# Altium schematic sheet TruckCape J1708 and more CAN.SchDoc
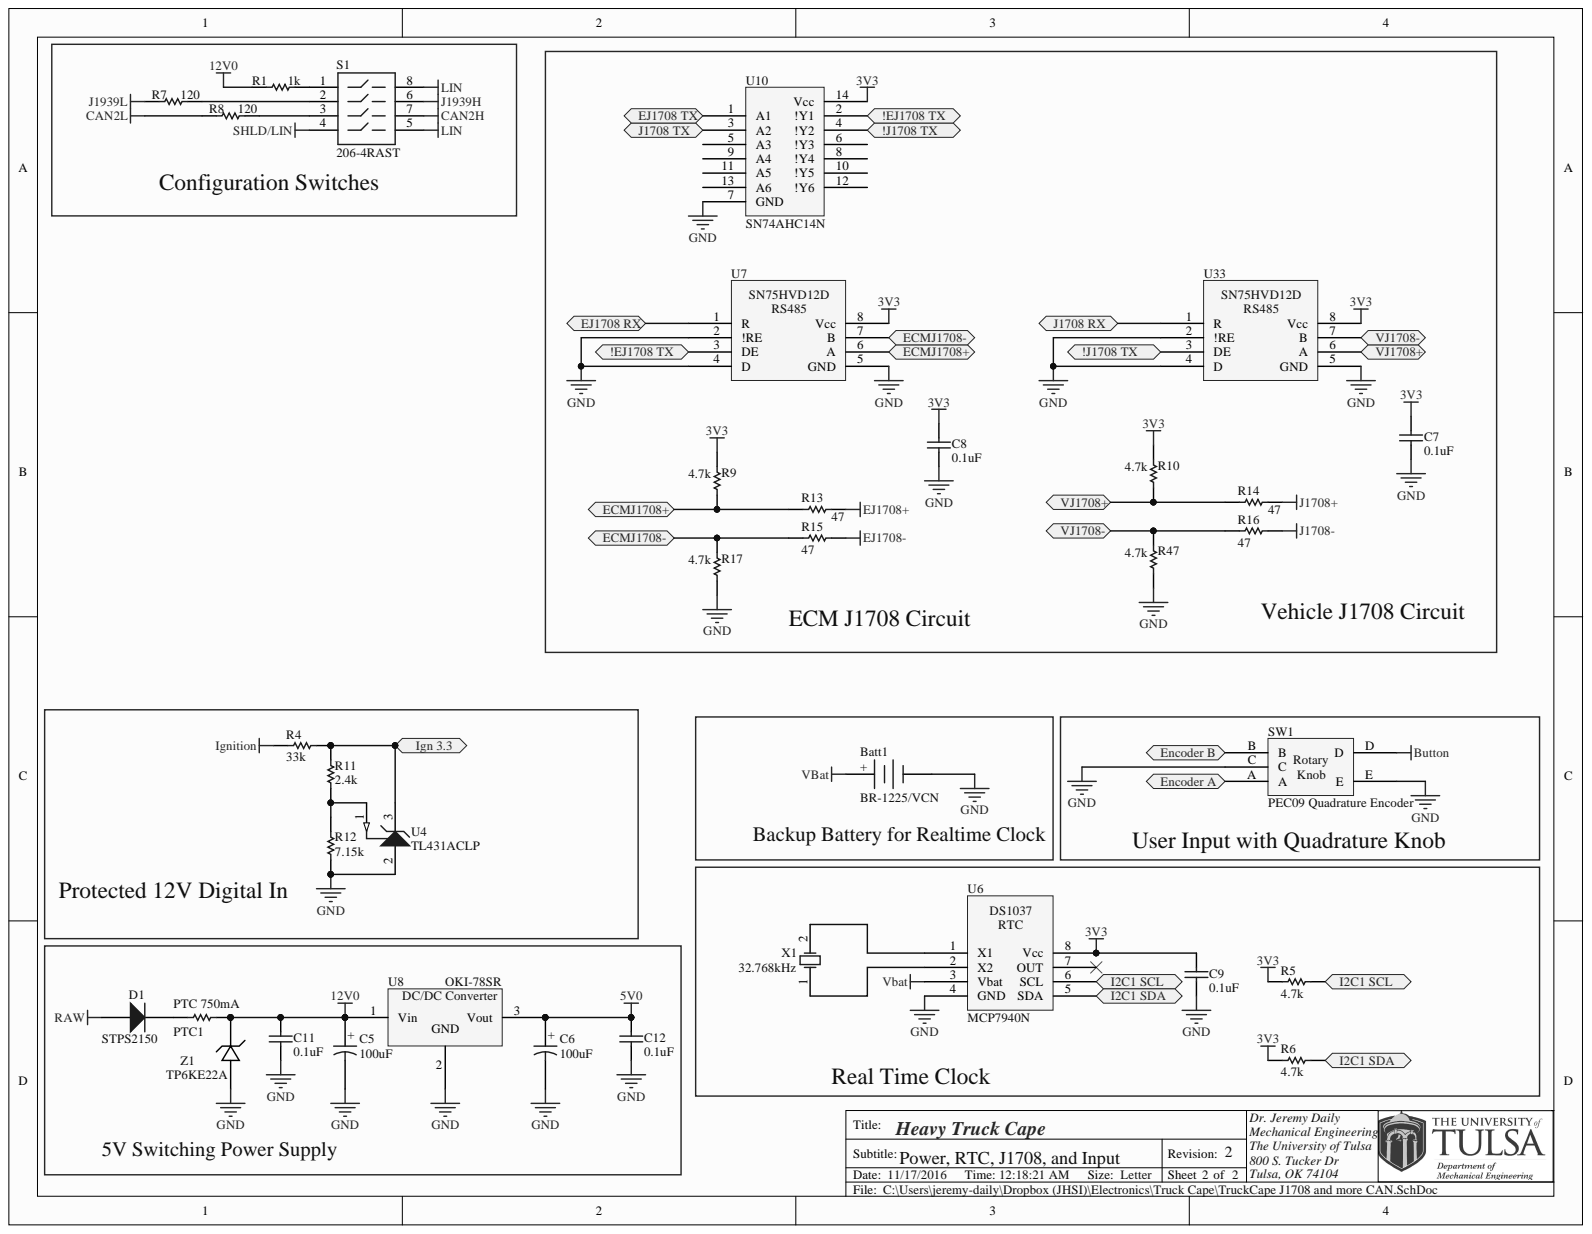
[diagram p0: TruckCape J1708 and more CAN.SchDoc]

## Parts
Batt1  BR-1225/VCN  [Coin Cell BR-1225/VCN]
C5  100uF  [Elec. Cap 5 x 10mm]
C6  100uF  [Elec. Cap 5 x 10mm]
C7  0.1uF  [CAPR5-4X5]
C8  0.1uF  [CAPR5-4X5]
C9  0.1uF  [CAPR5-4X5]
C11  0.1uF  [CAPR5-4X5]
C12  0.1uF  [CAPR5-4X5]
D1  STPS2150  [DO-41]
PTC1  PTC 750mA  [PTC]
R1  1k  [Axial-0.4]
R4  33k  [Axial-0.4]
R5  4.7k  [Axial-0.4]
R6  4.7k  [Axial-0.4]
R7  120  [Axial-0.4]
R8  120  [Axial-0.4]
R9  4.7k  [Axial-0.4]
R10  4.7k  [Axial-0.4]
R11  2.4k  [Axial-0.4]
R12  7.15k  [Axial-0.4]
R13  47  [Axial-0.4]
R14  47  [Axial-0.4]
R15  47  [Axial-0.4]
R16  47  [Axial-0.4]
R17  4.7k  [Axial-0.4]
R47  4.7k  [Axial-0.4]
S1  206-4RAST  [HDR2X4_CEN]
SW1  PEC09 Quadrature Encoder  [PEC09 Series Encoder]
U4  TL431ACLP  [TI-LP3]
U6  MCP7940N  [PDIP300-P8]
U7  SN75HVD12DR  [PDIP300-P8]
U8  OKI-78SR  [OKI-78SR]
U10  SN74AHC14N  [N14A]
U33  SN75HVD12DR  [PDIP300-P8]
X1  32.768kHz  [R38]
Z1  TP6KE22A  [DIODE-0.7]

## Ports
!EJ1708 TX, !J1708 TX, ECMJ1708+, ECMJ1708-, EJ1708 RX, EJ1708 TX, ENCODER A, ENCODER B, I2C1 SCL, I2C1 SDA, IGN 3.3, J1708 RX, J1708 TX, VJ1708+, VJ1708-

## Nets
3V3: C7.2 C8.2 C9.2 R5.2 R6.2 R9.1 R10.1 U6.8(Vcc) U7.8(Vcc) U10.14(Vcc) U33.8(Vcc)
5V0: C6.1 C12.2 U8.3
12V0: C5.1 C11.2 PTC1.2 R1.2 U8.1 Z1.2
BUTTON: SW1.D
CAN2H: S1.7
CAN2L: R8.2
EJ1708+: R13.2
EJ1708-: R15.2
GND: Batt1.2 C5.2 C6.2 C7.1 C8.1 C9.1 C11.1 C12.1 R12.1 R17.2 R47.2 SW1.C SW1.E U4.2 U6.4(GND) U7.2(!RE) U7.4(D) U7.5(GND) U8.2 U10.7(GND) U33.2(!RE) U33.4(D) U33.5(GND) Z1.1
IGNITION: R4.1
J1708+: R14.2
J1708-: R16.2
J1939H: S1.6
J1939L: R7.1
LIN: S1.5 S1.8
RAW: D1.1
SHLD/LIN: S1.4
VBAT: Batt1.1 U6.3(Vbat)
!EJ1708 TX: U7.3(DE) U10.2(!Y1) ; port !EJ1708 TX
!J1708 TX: U10.4(!Y2) U33.3(DE) ; port !J1708 TX
EJ1708 RX: U7.1(R) ; port EJ1708 RX
EJ1708 TX: U10.1(A1) ; port EJ1708 TX
I2C1 SCL: R5.1 U6.6(SCL) ; port I2C1 SCL
I2C1 SDA: R6.1 U6.5(SDA) ; port I2C1 SDA
J1708 RX: U33.1(R) ; port J1708 RX
J1708 TX: U10.3(A2) ; port J1708 TX
NetD1_2: D1.2 PTC1.1
NetR1_1: R1.1 S1.1
NetR4_2: R4.2 R11.2 U4.3 ; port IGN 3.3
NetR7_2: R7.2 S1.2
NetR8_1: R8.1 S1.3
NetR9_2: R9.2 R13.1 U7.6(A)
NetR10_2: R10.2 R14.1 U33.6(A)
NetR11_1: R11.1 R12.2 U4.1
NetR15_1: R15.1 R17.1 U7.7(B)
NetR16_1: R16.1 R47.1 U33.7(B)
NetSW1_A: SW1.A ; port ENCODER A
NetSW1_B: SW1.B ; port ENCODER B
NetU6_1: U6.1(X1) X1.2
NetU6_2: U6.2(X2) X1.1

Not connected: U6 7(OUT); U10 5(A3) 6(!Y3) 8(!Y4) 9(A4) 10(!Y5) 11(A5) 12(!Y6) 13(A6)

## Library data of the parts
Batt1 = CR2032 Battery Holder: Lithium Battery Non-Rechargeable (Primary) 3V Coin, 12.5mm; Manufacturer: Panasonic; Component Kind: Standard; Library Name: SSS Integrated Library.IntLib; PartNumber: BR-1225/VCN; Supplier 1: Digi-Key; Supplier Part Number 1: P192-ND
C5 C6 = Electrolytic Cap: CAP ALUM 470UF 20% 63V RADIAL; Manufacturer: Panasonic Electronic Components; PartNumber: EEU-FC1J471; Component Kind: Standard; Library Name: SSS Integrated Library.IntLib; Supplier 1: Digi-Key; Supplier Part Number 1: P10352-ND
C7-C9 C11 C12 = Cap: CAP CER 0.1UF 50V 10% X7R 0603; Manufacturer: Murata Electronics North America; PartNumber: GRM188R71H104KA93D; Component Kind: Standard; Library Name: SSS Schematic Library.SchLib; Signal Integrity: Cap; Simulation: CAP; Supplier 1: Digi-Key; Supplier Part Number 1: 490-1519-1-ND
D1 = Diode 1N4003: Schottky Diodes & Rectifiers 2.0 Amp 150 Volt; Manufacturer: STMicroelectronics; Component Kind: Standard; Library Name: Miscellaneous Devices.IntLib; PartNumber: STPS2150; Signal Integrity: 1N4003; Simulation: 1N4003; Supplier 1: Mouser; Supplier Part Number 1: 511-STPS2150
PTC1 = SMD Resistor: PTC RESTTBLE 0.75A 16V CHIP 1206; Manufacturer: Bel Fuse Inc; PartNumber: 0ZCJ0075AF2E; Component Kind: Standard; Library Name: SSS Schematic Library.SchLib; Supplier 1: Digi-Key; Supplier Part Number 1: 507-1805-1-ND
R1 R7 R8 = Chip Resistor: RES SMD 120 OHM 1% 1/2W 0805; Manufacturer: Panasonic Electronic Components; PartNumber: ERJ-P6WF1200V; Component Kind: Standard; Library Name: SSS Schematic Library.SchLib; Supplier 1: Digi-Key; Supplier Part Number 1: P16874CT-ND
R4-R6 R9 R10 R17 R47 = Chip Resistor: RES SMD 4.7K OHM 1% 1/10W 0603; Manufacturer: Panasonic Electronic Components; PartNumber: ERJ-3EKF4701V; Component Kind: Standard; Library Name: SSS Schematic Library.SchLib; Supplier 1: Digi-Key; Supplier Part Number 1: P4.70KHCT-ND
R11 = Chip Resistor: RES SMD 2.4K OHM 1% 1/10W 0603; Manufacturer: Panasonic Electronic Components; PartNumber: ERJ-3EKF2401V; Component Kind: Standard; Library Name: SSS Integrated Library.IntLib; Supplier 1: Digi-Key; Supplier Part Number 1: P2.40KHCT-ND
R12 = Chip Resistor: RES SMD 7.15K OHM 1% 1/10W 0603; Manufacturer: Panasonic Electronic Components; PartNumber: ERJ-3EKF7151V; Component Kind: Standard; Library Name: SSS Integrated Library.IntLib; Supplier 1: Digi-Key; Supplier Part Number 1: P7.15KHCT-ND
R13-R16 = Chip Resistor: RES SMD 47 OHM 1% 1/4W 0603; Manufacturer: Panasonic Electronic Components; PartNumber: ERJ-PA3F47R0V; Component Kind: Standard; Library Name: SSS Schematic Library.SchLib; Supplier 1: Digi-Key; Supplier Part Number 1: P47.00BYCT-ND
S1 = SW-DIP4: Dip Switch SPST 4 Position Through Hole, Right Angle Slide (Standard) Actuator 50mA 24VDC; Manufacturer: CTS Electrocomponents; Component Kind: Standard; LatestRevisionDate: 17-Jul-2002; LatestRevisionNote: Re-released for DXP Platform.; Library Name: Miscellaneous Devices.IntLib; PackageReference: DIP-8; Part Number: 206-4RAST; PartNumber: 206-4RAST; Published: 24-Mar-1999; Simulation: dpsw4; Supplier 1: Digi-Key; Supplier Part Number 1: CT2064RAST-ND; Publisher: Altium Limited
SW1 = Quadrature PEC09: ENCODER INCREMENTAL W/SWITCH 9MM; Manufacturer: Bourns Inc.; PartNumber: PEC09-2220F-S0012; Component Kind: Standard; Library Name: SSS Integrated Library.IntLib; Supplier 1: Digi-Key; Supplier Part Number 1: PEC09-2220F-S0012-ND
U4 = TL431ACLP: Adjustable Precision Shunt Regulator, 34 ppm / degC, 100 mA, 0 to 70 degC, 3-pin TO-92 (LP), Pb-Free (RoHS); Manufacturer: Texas Instruments; Initial Accuracy @ 25/deg C(%): 1; Iout/Iz(Max)(mA): 100; Min Iz for Regulation(uA): 600; Rating: Catalog; SubFamily: Shunt Voltage Reference; Temp Coeff(Max)(ppm/ degree C): 92; Temp Coeff(Typ)(ppm/ degree C): 34; VO Adj(Max)(V): 36; VO Adj(Min)(V): 2.495; Code_JEDEC: TO-226; Component Kind: Standard; ComponentLink1Description: Manufacturer URL; ComponentLink1URL: http://www.ti.com/; ComponentLink2Description: Datasheet; ComponentLink2URL: http://www.ti.com/general/docs/lit/getliterature.tsp?genericPartNumber=TL431A&fileType=pdf; ComponentLink3Description: Package Specification; ComponentLink3URL: http://www.ti.com/litv/pdf/msot002a; DatasheetVersion: SLVS543M; Eco-Plan: Pb-Free (RoHS); Library Name: TI Power Management Shunt Voltage Reference.IntLib; Mounting Technology: Through Hole; PackageDescription: Plastic Cylindrical Package, Formed Lead Option (TO-92); PackageReference: LP3; PackageVersion: 4040001-2/C; PartNumber: TL431ACLP; Supplier 1: Digi-Key; Supplier 2: Farnell; Supplier 3: Farnell; Supplier 4: Mouser; Supplier Part Number 1: 296-1287-ND; Supplier Part Number 2: 9593543; Supplier Part Number 3: 9593543RL; Supplier Part Number 4: 595-TL431ACLP
U6 = DS1307 RTC: Real Time Clock (RTC) IC Clock/Calendar 64B I²C, 2-Wire Serial 8-DIP (0.300", 7.62mm); Manufacturer: Microchip Technology; PartNumber: MCP7940N-I/P; Component Kind: Standard; Library Name: SSS Schematic Library.SchLib; Supplier 1: Digi-Key; Supplier Part Number 1: MCP7940N-I/P-ND
U7 U33 = SN75HVD12D (3.3v RS485): IC 3.3V DIFF TXRX 8-SOIC; Manufacturer: Texas Instruments; PartNumber: SN65HVD12P; Component Kind: Standard; Library Name: SSS Schematic Library.SchLib; Supplier 1: Digi-Key; Supplier Part Number 1: 296-13862-5-ND
U8 = 7805: 5V DC/DC Converter (7805 replacement); Manufacturer: Murata Power Solutions Inc.; PartNumber: OKI-78SR-5/1.5-W36H-C; Component Kind: Standard; Library Name: SSS Integrated Library.IntLib; Supplier 1: Digi-Key; Supplier 2: Digi-Key; Supplier Part Number 1: 811-2692-ND; Supplier Part Number 2: 811-2692-ND
U10 = 74VHC14 Hex Inverter: Inverter IC 6 Channel Schmitt Trigger 14-PDIP; Manufacturer: Texas Instruments; Component Kind: Standard; Library Name: SSS Integrated Library.IntLib; PartNumber: SN74AHC14N; Supplier 1: Digi-Key; Supplier Part Number 1: 296-4550-5-ND
X1 = Crystal Oscillator: CRYSTAL 32.768KHZ 12.5PF SMD; Manufacturer: ECS Inc; PartNumber: ECS-.327-12.5-39-TR; Component Kind: Standard; Library Name: SSS Schematic Library.SchLib; Supplier 1: Digi-Key; Supplier Part Number 1: XC1658CT-ND
Z1 = Zener: Component Kind: Standard; Library Name: SSS Integrated Library.IntLib; Supplier 1: Digi-Key
